# Altium schematic sheet [13] - AUDIO.SchDoc("[13] - AUDIO")
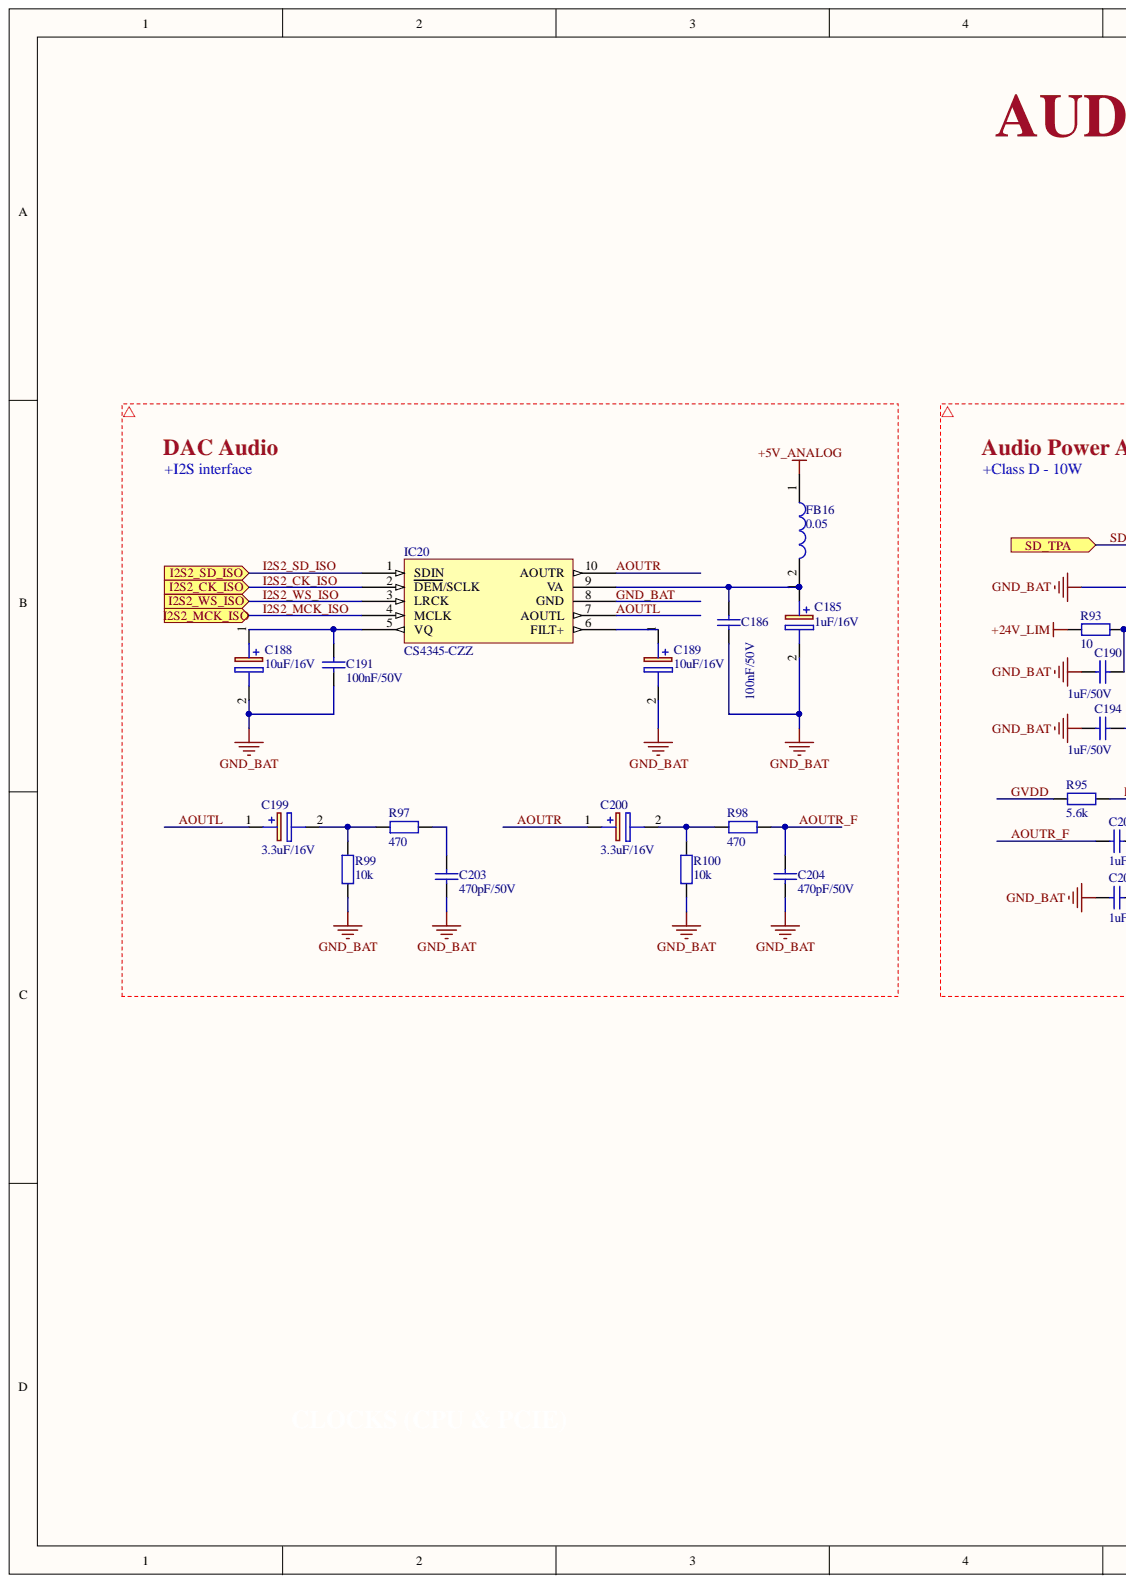
[diagram p0: [13] - AUDIO.SchDoc, left]
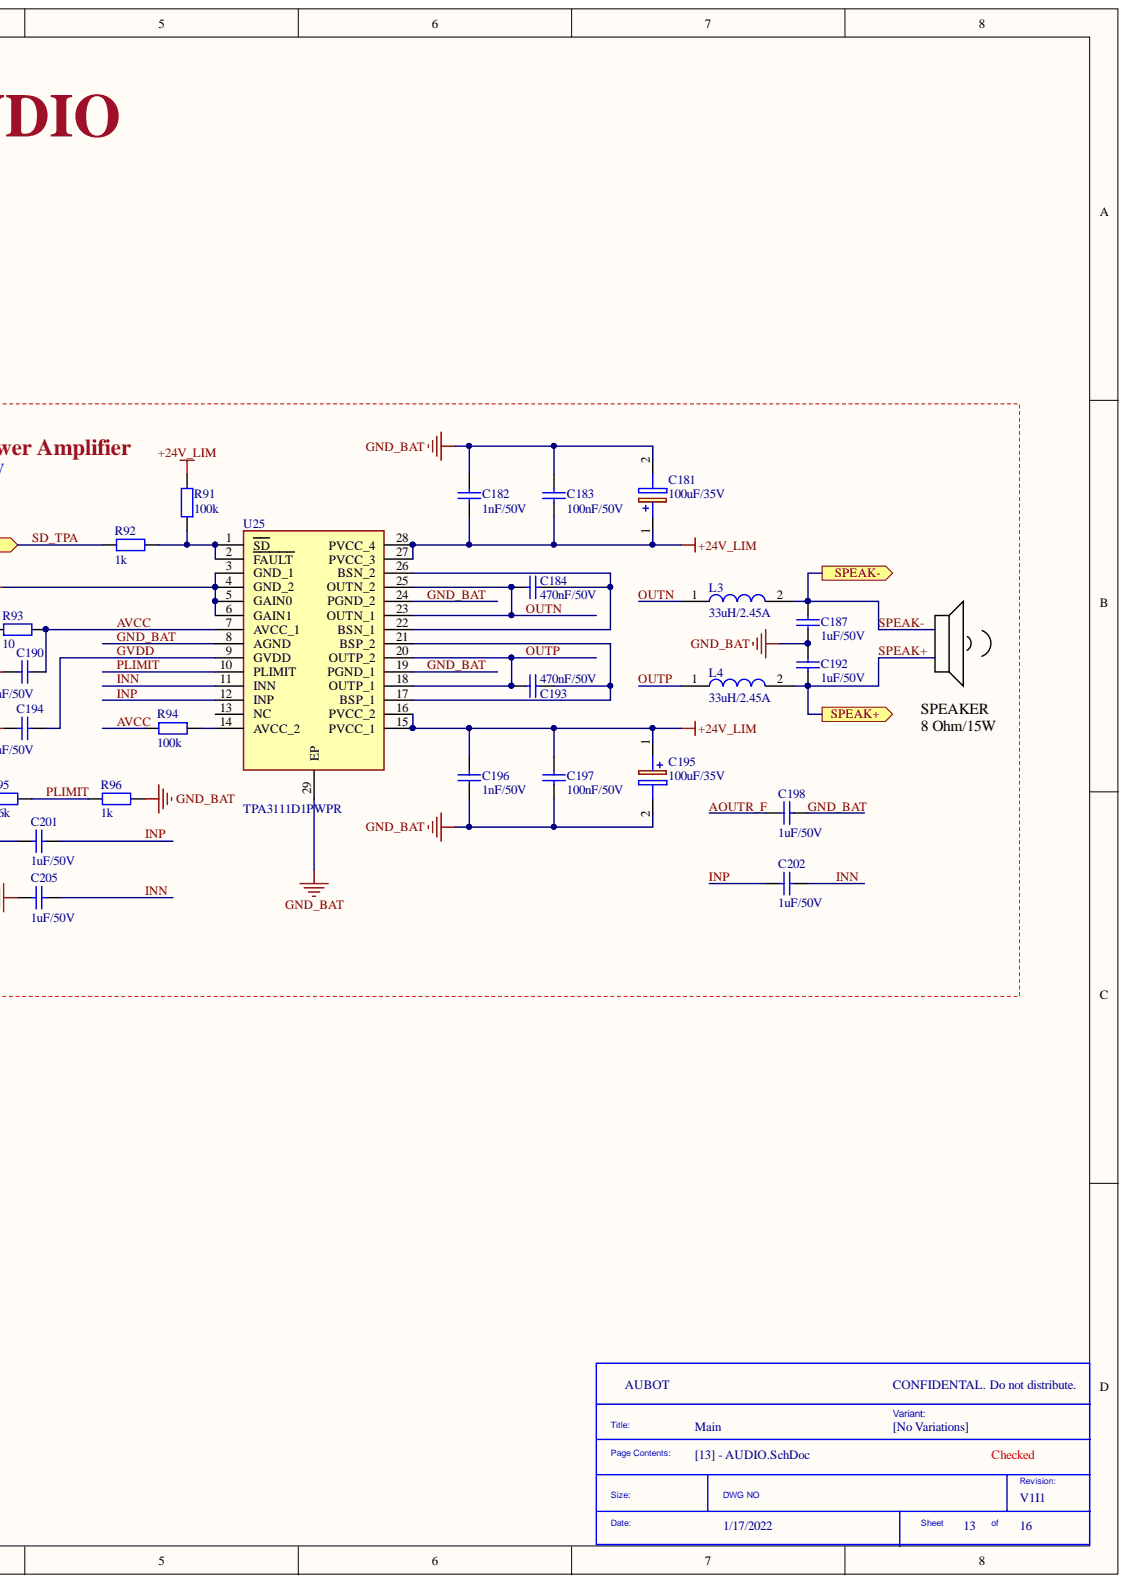
[diagram p1: [13] - AUDIO.SchDoc, right]

## Parts
C181  100uF/35V  [CAP 6.3X11]
C182  1nF/50V  [CAP 0805]
C183  100nF/50V  [CAP 0805]
C184  470nF/50V  [CAP 0805]
C185  1uF/16V  [CAP TANT 1206]
C186  100nF/50V  [CAP 0805]
C187  1uF/50V  [CAP 0805]
C188  10uF/16V  [CAP TANT 1206]
C189  10uF/16V  [CAP TANT 1206]
C190  1uF/50V  [CAP 0805]
C191  100nF/50V  [CAP 0805]
C192  1uF/50V  [CAP 0805]
C193  470nF/50V  [CAP 0805]
C194  1uF/50V  [CAP 0805]
C195  100uF/35V  [CAP 6.3X11]
C196  1nF/50V  [CAP 0805]
C197  100nF/50V  [CAP 0805]
C198  1uF/50V  [CAP 0805]
C199  3.3uF/16V  [CAP TANT 1206]
C200  3.3uF/16V  [CAP TANT 1206]
C201  1uF/50V  [CAP 0805]
C202  1uF/50V  [CAP 0805]
C203  470pF/50V  [CAP 0805]
C204  470pF/50V  [CAP 0805]
C205  1uF/50V  [CAP 0805]
FB16  0.05  [RES 0603]
IC20  CS4345-CZZ  [TSSOP-10]
L3  33uH/2.45A  [INDUCTOR RLB0913]
L4  33uH/2.45A  [INDUCTOR RLB0913]
R91  100k  [RES 0805]
R92  1k  [RES 0805]
R93  10  [RES 0805]
R94  100k  [RES 0805]
R95  5.6k  [RES 0805]
R96  1k  [RES 0805]
R97  470  [RES 0805]
R98  470  [RES 0805]
R99  10k  [RES 0805]
R100  10k  [RES 0805]
U25  TPA3111D1PWPR  [HTSSOP-28_POWERPAD]

## Ports
I2S2_CK_ISO, I2S2_MCK_ISO, I2S2_SD_ISO, I2S2_WS_ISO, SD_TPA, SPEAK+, SPEAK-

## Nets
+5V_ANALOG: FB16.1
+24V_LIM: C181.1 C182.1 C183.2 C195.1 C196.1 C197.1 R91.2 R93.1 U25.15(PVCC_1) U25.16(PVCC_2) U25.27(PVCC_3) U25.28(PVCC_4)
AOUTL: C199.1 IC20.7(AOUTL)
AOUTR: C200.1 IC20.10(AOUTR)
AOUTR_F: C198.2 C201.1 C204.1 R98.2
AVCC: C190.1 R93.2 R94.2 U25.7(AVCC_1)
GND_BAT: C181.2 C182.2 C183.1 C185.2 C186.2 C187.2 C188.2 C189.2 C190.2 C191.1 C192.1 C194.1 C195.2 C196.2 C197.2 C198.1 C203.2 C204.2 C205.1 IC20.8(GND) R96.2 R99.2 R100.2 U25.3(GND_1) U25.4(GND_2) U25.5(GAIN0) U25.6(GAIN1) U25.8(AGND) U25.19(PGND_1) U25.24(PGND_2) U25.29(EP)
GVDD: C194.2 R95.1 U25.9(GVDD)
I2S2_CK_ISO: IC20.2(DEM/SCLK) ; port I2S2_CK_ISO
I2S2_MCK_ISO: IC20.4(MCLK) ; port I2S2_MCK_ISO
I2S2_SD_ISO: IC20.1(SDIN) ; port I2S2_SD_ISO
I2S2_WS_ISO: IC20.3(LRCK) ; port I2S2_WS_ISO
INN: C202.1 C205.2 U25.11(INN)
INP: C201.2 C202.2 U25.12(INP)
OUTN: C184.2 L3.1 U25.23(OUTN_1) U25.25(OUTN_2)
OUTP: C193.2 L4.1 U25.18(OUTP_1) U25.20(OUTP_2)
PLIMIT: R95.2 R96.1 U25.10(PLIMIT)
SD_TPA: R92.1 ; port SD_TPA
SPEAK+: C192.2 L4.2 ; port SPEAK+
SPEAK-: C187.1 L3.2 ; port SPEAK-
NetC184_1: C184.1 U25.22(BSN_1) U25.26(BSN_2)
NetC185_1: C185.1 C186.1 FB16.2 IC20.9(VA)
NetC188_1: C188.1 C191.2 IC20.5(VQ)
NetC189_1: C189.1 IC20.6(FILT+)
NetC193_1: C193.1 U25.17(BSP_1) U25.21(BSP_2)
NetC199_2: C199.2 R97.1 R99.1
NetC200_2: C200.2 R98.1 R100.1
NetC203_1: C203.1 R97.2
NetR91_1: R91.1 R92.2 U25.1(SD) U25.2(FAULT)
NetR94_1: R94.1 U25.14(AVCC_2)

Not connected: U25 13(NC)

## Library data of the parts
C181 C195 = Cap Aluminum 100uF/35V: Alum. Electrolytic Cap 100µF 35V 6.3mm Radial WCAP-ATG8; Lifetime 2000h +85°C; Manufacturer Part Number: 860010573007; Manufacturer: Wurth Electronics; Tolerance: 20%; Ripple Current: 231mA; Polarity: Polar; Life (Hours): 2000Hour; Capacitance: 100uF; Voltage Rating (DC): 35V; Voltage Rating: 35V; Supplier Part Number 1: 732-8737-1-ND; Supplier 1: Digi-Key; Stock 1: 5828; RoHS Compliant: Yes; RoHS: Yes; REACH SVHC: Unknown; Pricing 1: 1 = 0.14000, 50 = 0.12200, 100 = 0.10700, 250 = 0.09400, 500 = 0.08300 (USD); Packaging: Tape and Reel; Package Quantity: 1; Mount: Through Hole; Min Operating Temperature: -40°C; Max Operating Temperature: 85°C; Lead Pitch: 0.098inch; Height - Seated (Max): 0.492inch; Height: 11mm; Diameter: 6.3mm; ComponentLink3URL: http://www.farnell.com/datasheets/1879547.pdf?src-supplier=Element14; ComponentLink3Description: http://www.farnell.com/datasheets/1879547.pdf?src-supplier=Element14; ComponentLink2URL: http://katalog.we-online.de/pbs/datasheet/860010573007.pdf?src-supplier=Digi-Key; ComponentLink2Description: http://katalog.we-online.de/pbs/datasheet/860010573007.pdf?src-supplier=Digi-Key; ComponentLink1URL: http://www.farnell.com/datasheets/1879547.pdf?src-supplier=Farnell; ComponentLink1Description: http://www.farnell.com/datasheets/1879547.pdf?src-supplier=Farnell; Component Kind: Standard; Case/Package: Radial; Library Name: Hieu_Lib.IntLib
C182 C196 = Cap 0805 1nF/50V: Surface Mount Ceramic Multilayer Capacitor 0.001uF 10% X7R 50V 0805 Paper T/R; Manufacturer Part Number: CC0805KRX7R9BB102; Manufacturer: Yageo; Insulation Resistance: 100GR; Capacitance: 1nF; Width: 0.049inch; Voltage Rating (DC): 50V; Voltage Rating: 50V; Voltage: 50V; Tolerance: 10%; Thickness: 0.024inch; Termination: SMD/SMT; Supplier Part Number 1: 311-1127-1-ND; Supplier 1: Digi-Key; Stock 1: 629072; Series: CC; RoHS Compliant: Yes; RoHS: Yes; REACH SVHC: No SVHC; Radiation Hardening: No; Pricing 1: 1 = 0.10000, 10 = 0.07000, 50 = 0.03800, 100 = 0.03100, 500 = 0.02214, 1000 = 0.01739 (USD); Packaging: Tape and Reel; Package Quantity: 1; Number of Pins: 2; Mount: Surface Mount; Min Operating Temperature: -55°C; Max Operating Temperature: 125°C; Material: Ceramic; Length: 2mm; Lead Free: Lead Free; Height: 0.6mm; Halogen Free: Halogen Free; Dielectric Material: Ceramic; Dielectric: X7R; Depth: 1.25mm; ComponentLink7URL: https://datasheet.ciiva.com/16625/upy-gphc-x7r-6-3v-to-50v-8-16625547.pdf?src-supplier=Avnet+Europe; ComponentLink7Description: https://datasheet.ciiva.com/16625/upy-gphc-x7r-6-3v-to-50v-8-16625547.pdf?src-supplier=Avnet+Europe; ComponentLink6URL: https://datasheet.ciiva.com/144/mlccorderingcode-144618.pdf?src-supplier=Digi-Key; ComponentLink6Description: https://datasheet.ciiva.com/144/mlccorderingcode-144618.pdf?src-supplier=Digi-Key; ComponentLink5URL: https://datasheet.ciiva.com/144/mlccorderingcode-144616.pdf?src-supplier=Digi-Key; ComponentLink5Description: https://datasheet.ciiva.com/144/mlccorderingcode-144616.pdf?src-supplier=Digi-Key; ComponentLink4URL: https://datasheet.ciiva.com/144/mlccorderingcode-144617.pdf?src-supplier=Digi-Key; ComponentLink4Description: https://datasheet.ciiva.com/144/mlccorderingcode-144617.pdf?src-supplier=Digi-Key; ComponentLink3URL: https://datasheet.ciiva.com/46393/1489800-46393302.pdf?src-supplier=Element14; ComponentLink3Description: https://datasheet.ciiva.com/46393/1489800-46393302.pdf?src-supplier=Element14; ComponentLink2URL: https://datasheet.ciiva.com/28450/1489800-28450797.pdf?src-supplier=Element14; ComponentLink2Description: https://datasheet.ciiva.com/28450/1489800-28450797.pdf?src-supplier=Element14; ComponentLink1URL: https://datasheet.ciiva.com/11786/0900766b80d84f1d-11786074.pdf?src-supplier=RSComponents; ComponentLink1Description: https://datasheet.ciiva.com/11786/0900766b80d84f1d-11786074.pdf?src-supplier=RSComponents; Component Kind: Standard; Case Code (Metric): 2012; Case Code (Imperial): 0805; Case/Package: 0805; Library Name: Hieu_Lib.IntLib
C183 C186 C191 C197 = Cap 0805 100nF/50V: Ceramic capacitor SMD-0805 100nF /50V X7R# K +-10%, Samsung, RoHS; Manufacturer Part Number: CL21B104KBCNNNC; Voltage Rating (DC): 50V; Tolerance: 10%; Dielectric: X7R; Width: 0.049inch; Voltage Rating: 50V; Voltage: 50V; Thickness: 0.037inch; Supplier Part Number 1: C1711; Supplier 1: LCSC; Stock 1: 447650; Series: CL; RoHS Compliant: Yes; RoHS: Yes; Radiation Hardening: No; Pricing 1: 50 = 0.04090, 500 = 0.03130, 1500 = 0.02890, 5000 = 0.02580, 25000 = 0.02430, 50000 = 0.02410, 50 = 0.03950, 500 = 0.03020, 1500 = 0.02790, 5000 = 0.02490, 25000 = 0.02350, 50000 = 0.02330, 50 = 0.20680, 500 = 0.15820, 1500 = 0.14600, 5000 = 0.13020, 25000 = 0.12290, 50000 = 0.12170, 50 = 0.19640, 500 = 0.15020, 1500 = 0.13860, 5000 = 0.12360, 25000 = 0.11670, 50000 = 0.11550, 50 = 0.02640, 500 = 0.02020, 1500 = 0.01860, 5000 = 0.01660, 25000 = 0.01570, 50000 = 0.01550, 50 = 0.02300, 500 = 0.01760, 1500 = 0.01620, 5000 = 0.01440, 25000 = 0.01360, 50000 = 0.01350, 50 = 0.24740, 500 = 0.18920, 1500 = 0.17470, 5000 = 0.15570, 25000 = 0.14700, 50000 = 0.14560, 50 = 3.45220, 500 = 2.64000, 1500 = 2.43700, 5000 = 2.17300, 25000 = 2.05110, 50000 = 2.03080, 50 = 0.13070, 500 = 0.09990, 1500 = 0.09220, 5000 = 0.08230, 25000 = 0.07760, 50000 = 0.07690, 50 = 2.39050, 500 = 1.82810, 1500 = 1.68750, 5000 = 1.50470, 25000 = 1.42030, 50000 = 1.40620, 50 = 0.26740, 500 = 0.20450, 1500 = 0.18870, 5000 = 0.16830, 25000 = 0.15890, 50000 = 0.15730, 50 = 0.04230, 500 = 0.03230, 1500 = 0.02990, 5000 = 0.02660, 25000 = 0.02510, 50000 = 0.02490, 50 = 0.03188, 500 = 0.02438, 1500 = 0.02251, 5000 = 0.02007, 25000 = 0.01894, 50000 = 0.01875 (AUD); Packaging: Tape and Reel; Mount: Surface Mount; Min Operating Temperature: -55°C; Max Operating Temperature: 125°C; Material: Ceramic; Manufacturer: Samsung; Length: 2mm; Lead Free: Lead Free; Height: 0.85mm; Depth: 1.25mm; Contact Plating: Tin; Construction: Flat; ComponentLink2URL: https://datasheet.ciiva.com/29199/454152-da-01-en-vs-kondensator-0805-29199814.pdf?src-supplier=Conrad; ComponentLink2Description: https://datasheet.ciiva.com/29199/454152-da-01-en-vs-kondensator-0805-29199814.pdf?src-supplier=Conrad; ComponentLink1URL: https://datasheet.ciiva.com/25710/cl21b104kbcnnnc-25710182.pdf?src-supplier=Digi-Key; ComponentLink1Description: https://datasheet.ciiva.com/25710/cl21b104kbcnnnc-25710182.pdf?src-supplier=Digi-Key; Component Kind: Standard; Case Code (Metric): 2012; Case Code (Imperial): 0805; Case/Package: 0805; Capacitance: 100nF; Library Name: Hieu_Lib.IntLib
C184 C193 = Cap 0805 470nF/50V: MLCC- CAPACITANCE 474; Manufacturer Part Number: CC0805KKX7R9BB474; Manufacturer: Yageo; Voltage Rating (DC): 50V; Tolerance: 10%; Dielectric: X7R; Capacitance: 470nF; Width: 0.049inch; Voltage Rating: 50V; Thickness: 0.057inch; Termination: SMD/SMT; Supplier Part Number 1: 311-1889-1-ND; Supplier 1: Digi-Key; Stock 1: 39761; Series: CC; RoHS Compliant: Yes; RoHS: Yes; Resistor Type: Ceramic; Radiation Hardening: No; Pricing 1: 1 = 0.25000, 10 = 0.17100, 50 = 0.10240, 100 = 0.08650, 500 = 0.05920, 1000 = 0.05237 (USD); Packaging: Tape and Reel; Mount: Surface Mount; Min Operating Temperature: -55°C; Max Operating Temperature: 125°C; Length: 2mm; Lead Free: Lead Free; Height: 1.25mm; Halogen Free: Halogen Free; Depth: 1.25mm; Construction: Flat; ComponentLink2URL: https://datasheet.ciiva.com/13263/upy-gphc-x7r-6-3v-to-50v-8-12304-13263301.pdf?src-supplier=Mouser; ComponentLink2Description: https://datasheet.ciiva.com/13263/upy-gphc-x7r-6-3v-to-50v-8-12304-13263301.pdf?src-supplier=Mouser; ComponentLink1URL: https://datasheet.ciiva.com/26862/getdatasheetpartid-403680-26862969.pdf?src-supplier=Verical; ComponentLink1Description: https://datasheet.ciiva.com/26862/getdatasheetpartid-403680-26862969.pdf?src-supplier=Verical; Component Kind: Standard; Case Code (Metric): 2012; Case Code (Imperial): 0805; Case/Package: 0805; Library Name: Hieu_Lib.IntLib
C185 = Cap Tant 1206 1uF/16V: Cap Tant Solid 1uF 16V A CASE 10%( 3.2 X 1.6 X 1.6mm) Inward L SMD 3216-18 11 Ohm 125C T/R; Manufacturer Part Number: TAJA105K016RNJ; Manufacturer: Kyocera AVX; Tolerance: 20%; Polarity: Polar; Leakage Current: 500nA; ESR (Equivalent Series Resistance): 11R; Dissipation Factor: 4%; Dielectric Material: Tantalum; Width: 1.6mm; Weight: 0.000571oz; Voltage Rating (DC): 16V; Voltage Rating: 16V; Voltage: 16V; Termination: SMD/SMT; Supplier Part Number 1: 478-1649-1-ND; Supplier 1: Digi-Key; Stock 1: 10000; Series: TAJ; RoHS Compliant: Yes; RoHS: Yes; REACH SVHC: No SVHC; Radiation Hardening: No; Pricing 1: 1 = 0.37000, 10 = 0.25500, 50 = 0.17880, 100 = 0.15320, 500 = 0.11488, 1000 = 0.09701 (USD); Packaging: Tape and Reel; Package Quantity: 1; Number of Pins: 2; Mount: Surface Mount,PCB; Min Operating Temperature: -55°C; Max Operating Temperature: 125°C; Length: 3.2mm; Lead Pitch: 1.1mm; Lead Free: Lead Free; Height - Seated (Max): 0.071inch; Height: 1.6mm; Features: General Purpose; Depth: 1.6mm; ComponentLink9URL: https://datasheet.ciiva.com/7832/1680476-7832431.pdf?src-supplier=Element14; ComponentLink9Description: https://datasheet.ciiva.com/7832/1680476-7832431.pdf?src-supplier=Element14; ComponentLink8URL: https://datasheet.ciiva.com/7832/1703059-7832429.pdf?src-supplier=Element14; ComponentLink8Description: https://datasheet.ciiva.com/7832/1703059-7832429.pdf?src-supplier=Element14; ComponentLink7URL: https://datasheet.ciiva.com/7832/taj-7832811.pdf?src-supplier=Element14; ComponentLink7Description: https://datasheet.ciiva.com/7832/taj-7832811.pdf?src-supplier=Element14; ComponentLink6URL: https://datasheet.ciiva.com/18710/taj-eng-18710647.pdf?src-supplier=Distrelec; ComponentLink6Description: https://datasheet.ciiva.com/18710/taj-eng-18710647.pdf?src-supplier=Distrelec; ComponentLink5URL: https://datasheet.ciiva.com/263/taj-263409.pdf?src-supplier=Digi-Key; ComponentLink5Description: https://datasheet.ciiva.com/263/taj-263409.pdf?src-supplier=Digi-Key; ComponentLink4URL: https://datasheet.ciiva.com/7832/1703059-7832430.pdf?src-supplier=Element14; ComponentLink4Description: https://datasheet.ciiva.com/7832/1703059-7832430.pdf?src-supplier=Element14; ComponentLink3URL: https://datasheet.ciiva.com/7832/taj-7832810.pdf?src-supplier=Element14; ComponentLink3Description: https://datasheet.ciiva.com/7832/taj-7832810.pdf?src-supplier=Element14; ComponentLink2URL: https://datasheet.ciiva.com/263/taj-263411.pdf?src-supplier=Digi-Key; ComponentLink2Description: https://datasheet.ciiva.com/263/taj-263411.pdf?src-supplier=Digi-Key; ComponentLink1URL: https://datasheet.ciiva.com/263/taj-263410.pdf?src-supplier=Digi-Key; ComponentLink1Description: https://datasheet.ciiva.com/263/taj-263410.pdf?src-supplier=Digi-Key; Component Kind: Standard; Case Code (Metric): 3216; Case Code (Imperial): 1206; Case/Package: 1206; Capacitance: 1uF; Library Name: Hieu_Lib.IntLib
C187 C190 C192 C194 C198 C201 C202 C205 = Cap 0805 1uF/50V: 0805 1 uF 50 V ±10 % Tolerance X7R SMT Multilayer Ceramic Capacitor; Manufacturer Part Number: CC0805KKX7R9BB105; Manufacturer: Yageo; Voltage Rating (DC): 50V; Tolerance: 10%; Capacitance: 1uF; Width: 0.049inch; Weight: 0.000194oz; Voltage Rating: 50V; Thickness: 0.057inch; Termination: SMD/SMT; Supplier Part Number 1: 311-1886-1-ND; Supplier 1: Digi-Key; Stock 1: 174238; Series: CC; RoHS Compliant: Yes; RoHS: Yes; Resistor Type: Ceramic; Radiation Hardening: No; Pricing 1: 1 = 0.26000, 10 = 0.17900, 50 = 0.11920, 100 = 0.10130, 500 = 0.07152, 1000 = 0.06257 (USD); Packaging: Tape and Reel; Mount: Surface Mount; Min Operating Temperature: -55°C; Max Operating Temperature: 125°C; Length: 2mm; Lead Free: Lead Free; Height: 1.25mm; Halogen Free: Halogen Free; Dielectric: X7R; Depth: 1.25mm; Construction: Flat; ComponentLink1URL: https://datasheet.ciiva.com/13209/upy-gphc-x7r-6-3v-to-50v-8-12304-13209375.pdf?src-supplier=Mouser; ComponentLink1Description: https://datasheet.ciiva.com/13209/upy-gphc-x7r-6-3v-to-50v-8-12304-13209375.pdf?src-supplier=Mouser; Component Kind: Standard; Case Code (Metric): 2012; Case Code (Imperial): 0805; Case/Package: 0805; Library Name: Hieu_Lib.IntLib
C188 C189 = Cap Tant 1206 10uF/16V: Cap Tant Solid 10uF 16V A CASE 20% (3.2 X 1.6 X 1.6mm) Inward L SMD 3216-18 125C T/R; Manufacturer Part Number: TCA1C106M8R; Manufacturer: Rohm; ESR (Equivalent Series Resistance): 3.5R; Width: 0.063inch; Voltage Rating (DC): 16V; Voltage Rating: 16V; Tolerance: 20%; Supplier Part Number 1: 511-1473-1-ND; Supplier 1: Digi-Key; Stock 1: 10000; Series: TC; RoHS Compliant: Yes; RoHS: Yes; Radiation Hardening: No; Pricing 1: 1 = 0.50000, 10 = 0.35200, 50 = 0.26660, 100 = 0.23210, 500 = 0.17194, 1000 = 0.14616 (USD); Packaging: Tape and Reel; Package Quantity: 1; Mount: Surface Mount; Min Operating Temperature: -55°C; Max Operating Temperature: 125°C; Length: 0.126inch; Lead Free: Lead Free; Height - Seated (Max): 0.071inch; Height: 1.6mm; Features: General Purpose; Depth: 1.6mm; Construction: Flat; ComponentLink1URL: https://datasheet.ciiva.com/16395/tc-a-16395735.pdf?src-supplier=Future+Electronics; ComponentLink1Description: https://datasheet.ciiva.com/16395/tc-a-16395735.pdf?src-supplier=Future+Electronics; Component Kind: Standard; Case Code (Metric): 3216; Case Code (Imperial): 1206; Case/Package: 1206; Capacitance: 10uF; Library Name: Hieu_Lib.IntLib
C199 C200 = Cap Tant 1206 3.3uF/16V: Cap Tant Solid 3.3uF 16V A CASE 20% (3.2 X 1.6 X 1.6mm) Inward L SMD 3216-18 5 Ohm 125C T/R; Manufacturer Part Number: TAJA335M016RNJ; Manufacturer: Kyocera AVX; Polarity: Polar; Leakage Current: 500nA; ESR (Equivalent Series Resistance): 5R; Dissipation Factor: 6%; Width: 0.063inch; Voltage Rating (DC): 16V; Voltage Rating: 16V; Tolerance: 20%; Termination: SMD/SMT; Supplier Part Number 1: 581-TAJA335M016R; Supplier 1: Mouser; Stock 1: 7941; RoHS: Yes; Radiation Hardening: No; Pricing 1: 1 = 0.46000, 10 = 0.25600, 50 = 0.25600, 100 = 0.19400, 1000 = 0.12300, 10000 = 0.12100 (USD); Packaging: Tape and Reel; Package Quantity: 1; Number of Pins: 2; Mount: Surface Mount; Min Operating Temperature: -55°C; Max Operating Temperature: 125°C; Length: 3.2mm; Lead Free: Lead Free; Height - Seated (Max): 0.071inch; Height: 1.8mm; Features: General Purpose; Depth: 1.6mm; ComponentLink4URL: https://datasheet.ciiva.com/16492/taj-16492793.pdf?src-supplier=Future+Electronics; ComponentLink4Description: https://datasheet.ciiva.com/16492/taj-16492793.pdf?src-supplier=Future+Electronics; ComponentLink3URL: https://datasheet.ciiva.com/12884/taj-27497-12884895.pdf?src-supplier=Mouser; ComponentLink3Description: https://datasheet.ciiva.com/12884/taj-27497-12884895.pdf?src-supplier=Mouser; ComponentLink2URL: https://datasheet.ciiva.com/11301/taj-11301101.pdf?src-supplier=Avnet; ComponentLink2Description: https://datasheet.ciiva.com/11301/taj-11301101.pdf?src-supplier=Avnet; ComponentLink1URL: https://datasheet.ciiva.com/11244/taj-11244006.pdf?src-supplier=Avnet; ComponentLink1Description: https://datasheet.ciiva.com/11244/taj-11244006.pdf?src-supplier=Avnet; Component Kind: Standard; Case Code (Metric): 3216; Case Code (Imperial): 1206; Case/Package: 1206; Capacitance: 3.3uF; Library Name: Hieu_Lib.IntLib
C203 C204 = Cap 0805 470pF/50V: 0805 470 pF 50 V ±10 % Tolerance X7R SMT Multilayer Ceramic Capacitor; Manufacturer Part Number: CC0805KRX7R9BB471; Manufacturer: Yageo; Voltage Rating (DC): 50V; Tolerance: 10%; Insulation Resistance: 100GR; Capacitance: 470pF; Width: 1.25mm; Voltage Rating: 50V; Thickness: 0.024inch; Termination: SMD/SMT; Supplier Part Number 1: 311-1124-1-ND; Supplier 1: Digi-Key; Stock 1: 190340; Series: CC; RoHS Compliant: Yes; RoHS: Yes; Resistor Type: Ceramic; REACH SVHC: Unknown; Radiation Hardening: No; Pricing 1: 1 = 0.11000, 10 = 0.08000, 50 = 0.04540, 100 = 0.03760, 500 = 0.02638, 1000 = 0.02184 (USD); Packaging: Tape and Reel; Package Quantity: 1; Number of Pins: 2; Mount: Surface Mount; Min Operating Temperature: -55°C; Max Operating Temperature: 125°C; Material: Ceramic; Length: 2mm; Lead Free: Lead Free; Height: 0.6mm; Halogen Free: Halogen Free; Dielectric Material: Ceramic; Dielectric: X7R; Depth: 1.25mm; Construction: Flat; ComponentLink6URL: https://datasheet.ciiva.com/15189/getdatasheetpartid-299872-15189229.pdf?src-supplier=Verical; ComponentLink6Description: https://datasheet.ciiva.com/15189/getdatasheetpartid-299872-15189229.pdf?src-supplier=Verical; ComponentLink5URL: https://datasheet.ciiva.com/46396/1489800-46396332.pdf?src-supplier=Element14; ComponentLink5Description: https://datasheet.ciiva.com/46396/1489800-46396332.pdf?src-supplier=Element14; ComponentLink4URL: https://datasheet.ciiva.com/144/mlccorderingcode-144600.pdf?src-supplier=Digi-Key; ComponentLink4Description: https://datasheet.ciiva.com/144/mlccorderingcode-144600.pdf?src-supplier=Digi-Key; ComponentLink3URL: https://datasheet.ciiva.com/144/mlccorderingcode-144599.pdf?src-supplier=Digi-Key; ComponentLink3Description: https://datasheet.ciiva.com/144/mlccorderingcode-144599.pdf?src-supplier=Digi-Key; ComponentLink2URL: https://datasheet.ciiva.com/144/mlccorderingcode-144598.pdf?src-supplier=Digi-Key; ComponentLink2Description: https://datasheet.ciiva.com/144/mlccorderingcode-144598.pdf?src-supplier=Digi-Key; ComponentLink1URL: https://datasheet.ciiva.com/29148/445173-da-01-en-kerko-chip-0805-x7r-470pf-10proz-50vg-29148961.pdf?src-supplier=Conrad; ComponentLink1Description: https://datasheet.ciiva.com/29148/445173-da-01-en-kerko-chip-0805-x7r-470pf-10proz-50vg-29148961.pdf?src-supplier=Conrad; Component Kind: Standard; Case Code (Metric): 2012; Case Code (Imperial): 0805; Case/Package: 0805; Library Name: Hieu_Lib.IntLib
FB16 = Ferrite Bead 0603 30Ohm 100Mhz: FERRITE BEAD 30 OHM 0603 1LN / Ferrite Beads Multi-Layer 30Ohm 100MHz 1A 0.05Ohm DCR 0603 T/R; Manufacturer Part Number: BLM18PG300SN1D; Manufacturer: Murata; Tolerance: 25%; Shielding: Unshielded; Number of Lines: 1; Material: Steel,Ferrite; Impedance: 30R; DC Resistance-Max: 50mR; Width: 0.8mm; Test Frequency: 100MHz; Termination: SMD/SMT; Supplier Part Number 1: 490-1035-1-ND; Supplier 1: Digi-Key; Stock 1: 1731; Series: BLM18P; RoHS Compliant: Yes; RoHS: Yes; REACH SVHC: No SVHC; Radiation Hardening: No; Pricing 1: 1 = 0.10000, 10 = 0.08300, 25 = 0.07600, 50 = 0.06840, 100 = 0.05010, 250 = 0.03644, 500 = 0.03340, 1000 = 0.02884 (USD); Packaging: Tape and Reel; Package Quantity: 4000; Number of Pins: 2; Number of Circuits: 1; Min Operating Temperature: -55°C; Max Operating Temperature: 125°C; Max DC Current: 1A; Max Current Rating: 1A; Length: 1.6mm; Lead Free: Lead Free; Height: 0.8mm; Frequency: 100MHz; Depth: 0.8mm; DC Resistance (DCR): 50mR; DC Current: 1A; Current Rating: 1A; Core Material: Ferrite; ComponentLink11URL: https://datasheet.ciiva.com/8021/5148-8021486.pdf?src-supplier=Element14; ComponentLink11Description: https://datasheet.ciiva.com/8021/5148-8021486.pdf?src-supplier=Element14; ComponentLink10URL: https://datasheet.ciiva.com/17246/l0110s0100blm18p-17246940.pdf?src-supplier=Avnet+Europe; ComponentLink10Description: https://datasheet.ciiva.com/17246/l0110s0100blm18p-17246940.pdf?src-supplier=Avnet+Europe; ComponentLink9URL: https://datasheet.ciiva.com/8021/5148-8021484.pdf?src-supplier=Element14; ComponentLink9Description: https://datasheet.ciiva.com/8021/5148-8021484.pdf?src-supplier=Element14; ComponentLink8URL: https://datasheet.ciiva.com/1012/l0110s0100blm18p-1012121.pdf?src-supplier=Digi-Key; ComponentLink8Description: https://datasheet.ciiva.com/1012/l0110s0100blm18p-1012121.pdf?src-supplier=Digi-Key; ComponentLink7URL: https://datasheet.ciiva.com/1012/l0110s0100blm18p-1012120.pdf?src-supplier=Digi-Key; ComponentLink7Description: https://datasheet.ciiva.com/1012/l0110s0100blm18p-1012120.pdf?src-supplier=Digi-Key; ComponentLink6URL: https://datasheet.ciiva.com/16420/l0110s0100blm18p-16420743.pdf?src-supplier=Future+Electronics; ComponentLink6Description: https://datasheet.ciiva.com/16420/l0110s0100blm18p-16420743.pdf?src-supplier=Future+Electronics; ComponentLink5URL: https://datasheet.ciiva.com/1012/l0110s0100blm18p-1012119.pdf?src-supplier=Digi-Key; ComponentLink5Description: https://datasheet.ciiva.com/1012/l0110s0100blm18p-1012119.pdf?src-supplier=Digi-Key; ComponentLink4URL: https://datasheet.ciiva.com/3081/l0110s0100blm18p-3081636.pdf?src-supplier=Avnet; ComponentLink4Description: https://datasheet.ciiva.com/3081/l0110s0100blm18p-3081636.pdf?src-supplier=Avnet; ComponentLink3URL: https://datasheet.ciiva.com/3081/l0110s0100blm18p-3081635.pdf?src-supplier=Avnet; ComponentLink3Description: https://datasheet.ciiva.com/3081/l0110s0100blm18p-3081635.pdf?src-supplier=Avnet; ComponentLink2URL: https://datasheet.ciiva.com/19460/1845048-19460200.pdf?src-supplier=Jameco; ComponentLink2Description: https://datasheet.ciiva.com/19460/1845048-19460200.pdf?src-supplier=Jameco; ComponentLink1URL: https://datasheet.ciiva.com/11800/0900766b81275ca5-11800868.pdf?src-supplier=RSComponents; ComponentLink1Description: https://datasheet.ciiva.com/11800/0900766b81275ca5-11800868.pdf?src-supplier=RSComponents; Component Kind: Standard; Case Code (Metric): 1608; Case Code (Imperial): 0603; Case/Package: 0603; Library Name: Hieu_Lib.IntLib
IC20 = CS4345-CZZ: DAC 2-CH Delta-Sigma 24-bit 10-Pin TSSOP Tube; Manufacturer: Cirrus Logic; Supply Type: Single; Supply Current: 22mA; Sampling Rate: 192000S/s; Resolution: 24b; Polarity: Bipolar; Output Type: Voltage; Operating Supply Voltage: 5V; Number of DAC Channels: 2; Number of Bits: 24; Min Supply Voltage: 3V; Max Supply Voltage: 5.25V; Max Power Dissipation: 69mW; Interface: SPI,Serial; Input Type: SERIAL; Conversion Rate: 192KS/s; Termination: SMD/SMT; Supplier Part Number 1: 598-1052-5-ND; Supplier 1: Digi-Key; Stock 1: 13400; Series: Popguard®; RoHS Compliant: Yes; RoHS: Yes; REACH SVHC: No SVHC; Pricing 1: 1 = 4.02000, 10 = 3.60800, 25 = 3.41040, 100 = 2.95580, 250 = 2.80424, 500 = 2.51622, 1000 = 2.14500 (USD); Packaging: Rail/Tube; Package Quantity: 96; Output Polarity: Bipolar; Number of Pins: 10; Number of D/A Converters: 2; Number of Converters: 2; Number of Channels: 2; Nominal Supply Current: 22mA; Mount: Surface Mount; Min Operating Temperature: -10°C; Max Operating Temperature: 70°C; Manufacturer Part Number: CS4345-CZZ; Lead Free: Lead Free; Contact Plating: Tin,Matte; ComponentLink5URL: https://datasheet.ciiva.com/3041/cs4344-45-46-48-f1-3041726.pdf?src-supplier=Avnet; ComponentLink5Description: https://datasheet.ciiva.com/3041/cs4344-45-46-48-f1-3041726.pdf?src-supplier=Avnet; ComponentLink4URL: https://datasheet.ciiva.com/16606/cs4344-45-46-48-f1-16606870.pdf?src-supplier=Avnet+Europe; ComponentLink4Description: https://datasheet.ciiva.com/16606/cs4344-45-46-48-f1-16606870.pdf?src-supplier=Avnet+Europe; ComponentLink3URL: https://datasheet.ciiva.com/1502/88361-1502954.pdf?src-supplier=Farnell; ComponentLink3Description: https://datasheet.ciiva.com/1502/88361-1502954.pdf?src-supplier=Farnell; ComponentLink2URL: https://datasheet.ciiva.com/1494/88361-1494229.pdf?src-supplier=Element14; ComponentLink2Description: https://datasheet.ciiva.com/1494/88361-1494229.pdf?src-supplier=Element14; ComponentLink1URL: https://datasheet.ciiva.com/26805/cs4345-czz-26805026.pdf?src-supplier=IIIC; ComponentLink1Description: https://datasheet.ciiva.com/26805/cs4345-czz-26805026.pdf?src-supplier=IIIC; Component Kind: Standard; Case/Package: TSSOP; Library Name: Hieu_Lib.IntLib
L3 L4 = Inductor 33uH/2.45A: Inductor Power Wirewound 33uH 10% 1KHz 30Q-Factor Ferrite 2.45A 90mOhm DCR RDL Tray; Manufacturer Part Number: RLB0913-330K; Manufacturer: Bourns; Shielding: Unshielded; Self Resonant Frequency: 7.8MHz; Saturation Current: 1.6A; DC Resistance (DCR): 90mR; Tolerance: 10%; Test Frequency: 1kHz; Supplier Part Number 1: RLB0913-330K-ND; Supplier 1: Digi-Key; Stock 1: 0; RoHS Compliant: Yes; RoHS: Yes; Resistor Type: Wirewound; Pricing 1: 1 = 0.85000, 10 = 0.74500, 25 = 0.69160, 40 = 0.58525, 200 = 0.53210, 400 = 0.50020, 600 = 0.44698, 1000 = 0.36184, 2400 = 0.34056 (USD); Packaging: Tray; Mount: Through Hole; Min Operating Temperature: -55°C; Max Operating Temperature: 125°C; Inductance: 33uH; Current Rating: 2.45A; Core Material: Ferrite; ComponentLink2URL: https://www.bourns.com/docs/Product-Datasheets/rlb0913.pdf?src-supplier=Digi-Key; ComponentLink2Description: https://www.bourns.com/docs/Product-Datasheets/rlb0913.pdf?src-supplier=Digi-Key; ComponentLink1URL: http://www.farnell.com/datasheets/2240884.pdf?src-supplier=Farnell; ComponentLink1Description: http://www.farnell.com/datasheets/2240884.pdf?src-supplier=Farnell; Component Kind: Standard; Case/Package: Radial; Library Name: Hieu_Lib.IntLib
R91 R94 = Res 0805 100k Ohm 1%: Res Thick Film 0805 100K Ohm 1% 1/8W ±100ppm/°C Molded SMD Paper T/R; Manufacturer Part Number: RC0805FR-07100KL; Manufacturer: Yageo; Voltage Rating (DC): 150V; Resistance: 100kR; Power Rating: 125mW; Width: 0.049inch; Voltage Rating: 150V; Tolerance: 1%; Termination: SMD/SMT; Temperature Coefficient: 100ppm/°C; Technology: THICK FILM; Supplier Part Number 1: 311-100KCRCT-ND; Supplier 1: Digi-Key; Stock 1: 537111; Size Code: 0805; Series: RC; RoHS Compliant: Yes; RoHS: Yes; Resistor Type: Thick Film; Resistance Tolerance: 1%; REACH SVHC: Unknown; Pricing 1: 1 = 0.10000, 10 = 0.04200, 100 = 0.01690, 1000 = 0.00759, 2500 = 0.00659 (USD); Packaging: Tape and Reel; Package Quantity: 1; Number of Terminations: 2; Number of Pins: 2; Min Operating Temperature: -55°C; Military Standard: Not; Max Power Dissipation: 125mW; Max Operating Temperature: 155°C; Length: 2mm; Lead Pitch: 0.4mm; Lead Free: Lead Free; Height: 0.024inch; Features: Moisture Resistant; Depth: 1.25mm; Construction: Rectangular; ComponentLink11URL: https://datasheet.ciiva.com/16747/rc0805-16747226.pdf?src-supplier=Future+Electronics; ComponentLink11Description: https://datasheet.ciiva.com/16747/rc0805-16747226.pdf?src-supplier=Future+Electronics; ComponentLink10URL: https://datasheet.ciiva.com/7941/1697719-7941678.pdf?src-supplier=Element14; ComponentLink10Description: https://datasheet.ciiva.com/7941/1697719-7941678.pdf?src-supplier=Element14; ComponentLink9URL: https://datasheet.ciiva.com/7941/1697719-7941676.pdf?src-supplier=Element14; ComponentLink9Description: https://datasheet.ciiva.com/7941/1697719-7941676.pdf?src-supplier=Element14; ComponentLink8URL: https://datasheet.ciiva.com/12667/rc0805-12667001.pdf?src-supplier=Mouser; ComponentLink8Description: https://datasheet.ciiva.com/12667/rc0805-12667001.pdf?src-supplier=Mouser; ComponentLink7URL: https://datasheet.ciiva.com/2457/pyu-r-int-thick-7-2457017.pdf?src-supplier=Digi-Key; ComponentLink7Description: https://datasheet.ciiva.com/2457/pyu-r-int-thick-7-2457017.pdf?src-supplier=Digi-Key; ComponentLink6URL: https://datasheet.ciiva.com/2457/pyu-r-int-thick-7-2457019.pdf?src-supplier=Digi-Key; ComponentLink6Description: https://datasheet.ciiva.com/2457/pyu-r-int-thick-7-2457019.pdf?src-supplier=Digi-Key; ComponentLink5URL: https://datasheet.ciiva.com/2457/pyu-r-int-thick-7-2457018.pdf?src-supplier=Digi-Key; ComponentLink5Description: https://datasheet.ciiva.com/2457/pyu-r-int-thick-7-2457018.pdf?src-supplier=Digi-Key; ComponentLink4URL: https://datasheet.ciiva.com/22747/444164-22747534.pdf?src-supplier=Element14; ComponentLink4Description: https://datasheet.ciiva.com/22747/444164-22747534.pdf?src-supplier=Element14; ComponentLink3URL: https://datasheet.ciiva.com/7660/38627-7660796.pdf?src-supplier=Element14; ComponentLink3Description: https://datasheet.ciiva.com/7660/38627-7660796.pdf?src-supplier=Element14; ComponentLink2URL: https://datasheet.ciiva.com/7941/1686128-7941677.pdf?src-supplier=Element14; ComponentLink2Description: https://datasheet.ciiva.com/7941/1686128-7941677.pdf?src-supplier=Element14; ComponentLink1URL: https://datasheet.ciiva.com/11808/0900766b8106beab-11808858.pdf?src-supplier=RSComponents; ComponentLink1Description: https://datasheet.ciiva.com/11808/0900766b8106beab-11808858.pdf?src-supplier=RSComponents; Component Kind: Standard; Case Code (Metric): 2012; Case Code (Imperial): 0805; Case/Package: 0805; Library Name: Hieu_Lib.IntLib
R92 R96 = Res 0805 1k Ohm 1%: Res Thick Film 0805 1KOhm 1% 0.125W(1/8W) 100ppm/C Epoxy Pad SMD T/R; Manufacturer Part Number: RC0805FR-071KL; Manufacturer: Yageo; Voltage Rating (DC): 150V; Temperature Coefficient: 100ppm/°C; Resistance: 1kR; Power Rating: 125mW; Width: 0.049inch; Voltage Rating: 150V; Tolerance: 1%; Termination: SMD/SMT; Supplier Part Number 1: 311-1.00KCRCT-ND; Supplier 1: Digi-Key; Stock 1: 2324983; Size Code: 0805; Series: RC; RoHS Compliant: Yes; RoHS: Yes; Resistor Type: Thick Film; Resistance Tolerance: 1%; REACH SVHC: Unknown; Pricing 1: 1 = 0.10000, 10 = 0.04200, 100 = 0.01690, 1000 = 0.00759, 2500 = 0.00659 (USD); Packaging: Tape and Reel; Package Quantity: 1; Number of Terminations: 2; Number of Pins: 2; Min Operating Temperature: -55°C; Military Standard: Not; Max Power Dissipation: 125mW; Max Operating Temperature: 155°C; Length: 0.079inch; Lead Free: Lead Free; Height: 0.024inch; Features: Moisture Resistant; Depth: 1.25mm; Construction: Rectangular; Component Kind: Standard; Case Code (Metric): 2012; Case Code (Imperial): 0805; Case/Package: 0805; Library Name: Hieu_Lib.IntLib
R93 = Res 0805 10 Ohm 1%: Res SMD 0805 10R 1% 0.125W T.C.100PPM; Manufacturer Part Number: RC0805FR-0710RL; Manufacturer: Yageo; Voltage Rating (DC): 150V; Temperature Coefficient: 200ppm/°C; Power Rating: 125mW; Width: 1.25mm; Voltage Rating: 150V; Tolerance: 1%; Termination: SMD/SMT; Technology: THICK FILM; Supplier Part Number 1: 311-10.0CRCT-ND; Supplier 1: Digi-Key; Stock 1: 0; Size Code: 0805; Series: RC; RoHS Compliant: Yes; RoHS: Yes; Resistor Type: Thick Film; Resistance Tolerance: 1%; Resistance: 10R; REACH SVHC: No SVHC; Packaging: Tape and Reel; Package Quantity: 1; Number of Terminations: 2; Number of Pins: 2; Min Operating Temperature: -55°C; Max Power Dissipation: 125mW; Max Operating Temperature: 155°C; Length: 2mm; Lead Free: Lead Free; Height: 0.5mm; Features: Moisture Resistant; Depth: 1.25mm; Construction: Rectangular; ComponentLink9URL: https://datasheet.ciiva.com/2457/pyu-r-int-thick-7-2457099.pdf?src-supplier=Digi-Key; ComponentLink9Description: https://datasheet.ciiva.com/2457/pyu-r-int-thick-7-2457099.pdf?src-supplier=Digi-Key; ComponentLink8URL: https://datasheet.ciiva.com/2457/pyu-r-int-thick-7-2457098.pdf?src-supplier=Digi-Key; ComponentLink8Description: https://datasheet.ciiva.com/2457/pyu-r-int-thick-7-2457098.pdf?src-supplier=Digi-Key; ComponentLink7URL: https://datasheet.ciiva.com/12555/rc0805-257173-12555112.pdf?src-supplier=Mouser; ComponentLink7Description: https://datasheet.ciiva.com/12555/rc0805-257173-12555112.pdf?src-supplier=Mouser; ComponentLink6URL: https://datasheet.ciiva.com/46447/444164-46447565.pdf?src-supplier=Element14; ComponentLink6Description: https://datasheet.ciiva.com/46447/444164-46447565.pdf?src-supplier=Element14; ComponentLink5URL: https://datasheet.ciiva.com/2457/pyu-r-int-thick-7-2457100.pdf?src-supplier=Digi-Key; ComponentLink5Description: https://datasheet.ciiva.com/2457/pyu-r-int-thick-7-2457100.pdf?src-supplier=Digi-Key; ComponentLink4URL: https://datasheet.ciiva.com/46412/444164-46412859.pdf?src-supplier=Element14; ComponentLink4Description: https://datasheet.ciiva.com/46412/444164-46412859.pdf?src-supplier=Element14; ComponentLink3URL: https://datasheet.ciiva.com/7941/444164-7941688.pdf?src-supplier=Element14; ComponentLink3Description: https://datasheet.ciiva.com/7941/444164-7941688.pdf?src-supplier=Element14; ComponentLink2URL: https://datasheet.ciiva.com/7941/444164-7941687.pdf?src-supplier=Element14; ComponentLink2Description: https://datasheet.ciiva.com/7941/444164-7941687.pdf?src-supplier=Element14; ComponentLink1URL: https://datasheet.ciiva.com/11809/0900766b8106beab-11809370.pdf?src-supplier=RSComponents; ComponentLink1Description: https://datasheet.ciiva.com/11809/0900766b8106beab-11809370.pdf?src-supplier=RSComponents; Component Kind: Standard; Case Code (Metric): 2012; Case Code (Imperial): 0805; Case/Package: 0805; Library Name: Hieu_Lib.IntLib
R95 = Res 0805 5.6k Ohm 1%: Res Thick Film 0805 5.6K Ohm 1% 0.125W(1/8W) ±100ppm/C Pad SMD T/R; Manufacturer Part Number: RC0805FR-075K6L; Manufacturer: Yageo; Voltage Rating: 150V; Temperature Coefficient: 100ppm/°C; Resistance: 5.6kR; Power Rating: 125mW; Width: 0.049inch; Tolerance: 1%; Termination: SMD/SMT; Supplier Part Number 1: 311-5.60KCRCT-ND; Supplier 1: Digi-Key; Stock 1: 312924; Size Code: 0805; Series: RC; RoHS Compliant: Yes; RoHS: Yes; Resistor Type: Thick Film; Resistance Tolerance: 1%; REACH SVHC: Unknown; Pricing 1: 1 = 0.10000, 10 = 0.04200, 100 = 0.01690, 1000 = 0.00759, 2500 = 0.00659 (USD); Packaging: Tape and Reel; Package Quantity: 1; Number of Terminations: 2; Min Operating Temperature: -55°C; Military Standard: Not; Max Power Dissipation: 125mW; Max Operating Temperature: 155°C; Length: 2mm; Lead Free: Lead Free; Height: 0.024inch; Features: Moisture Resistant; Depth: 1.25mm; Construction: Rectangular; ComponentLink5URL: https://datasheet.ciiva.com/7941/444164-7941820.pdf?src-supplier=Element14; ComponentLink5Description: https://datasheet.ciiva.com/7941/444164-7941820.pdf?src-supplier=Element14; ComponentLink4URL: https://datasheet.ciiva.com/7941/444164-7941819.pdf?src-supplier=Element14; ComponentLink4Description: https://datasheet.ciiva.com/7941/444164-7941819.pdf?src-supplier=Element14; ComponentLink3URL: https://datasheet.ciiva.com/2519/pyu-r-int-thick-7-2519845.pdf?src-supplier=Digi-Key; ComponentLink3Description: https://datasheet.ciiva.com/2519/pyu-r-int-thick-7-2519845.pdf?src-supplier=Digi-Key; ComponentLink2URL: https://datasheet.ciiva.com/2519/pyu-r-int-thick-7-2519844.pdf?src-supplier=Digi-Key; ComponentLink2Description: https://datasheet.ciiva.com/2519/pyu-r-int-thick-7-2519844.pdf?src-supplier=Digi-Key; ComponentLink1URL: https://datasheet.ciiva.com/2519/pyu-r-int-thick-7-2519843.pdf?src-supplier=Digi-Key; ComponentLink1Description: https://datasheet.ciiva.com/2519/pyu-r-int-thick-7-2519843.pdf?src-supplier=Digi-Key; Component Kind: Standard; Case Code (Metric): 2012; Case Code (Imperial): 0805; Case/Package: 0805; Library Name: Hieu_Lib.IntLib
R97 R98 = Res 0805 470 Ohm 1%: Res Thick Film 0805 470 Ohm 1% 1/8W +/-100ppm/ßC Molded SMD Paper T/R; Manufacturer Part Number: RC0805FR-07470RL; Manufacturer: Yageo; Voltage Rating (DC): 150V; Temperature Coefficient: 100ppm/°C; Resistance: 470R; Power Rating: 125mW; Width: 1.25mm; Voltage Rating: 150V; Tolerance: 1%; Termination: SMD/SMT; Supplier Part Number 1: 311-470CRDKR-ND; Supplier 1: Digi-Key; Stock 1: 0; Size Code: 0805; Series: RC; RoHS Compliant: Yes; RoHS: Yes; Resistor Type: Thick Film; Resistance Tolerance: 1%; REACH SVHC: Unknown; Pricing 1: 1 = 0.10000, 10 = 0.04200, 100 = 0.01690, 1000 = 0.00759, 2500 = 0.00659 (USD); Packaging: Tape and Reel; Package Quantity: 5000; Number of Terminations: 2; Number of Pins: 2; Min Operating Temperature: -55°C; Military Standard: Not; Max Power Dissipation: 125mW; Max Operating Temperature: 155°C; Length: 2mm; Lead Pitch: 0.4mm; Lead Free: Lead Free; Height: 0.5mm; Features: Moisture Resistant; Depth: 1.25mm; Construction: Rectangular; ComponentLink7URL: https://datasheet.ciiva.com/16745/rc0805-16745229.pdf?src-supplier=Future+Electronics; ComponentLink7Description: https://datasheet.ciiva.com/16745/rc0805-16745229.pdf?src-supplier=Future+Electronics; ComponentLink6URL: https://datasheet.ciiva.com/46447/444164-46447580.pdf?src-supplier=Element14; ComponentLink6Description: https://datasheet.ciiva.com/46447/444164-46447580.pdf?src-supplier=Element14; ComponentLink5URL: https://datasheet.ciiva.com/7941/444164-7941798.pdf?src-supplier=Element14; ComponentLink5Description: https://datasheet.ciiva.com/7941/444164-7941798.pdf?src-supplier=Element14; ComponentLink4URL: https://datasheet.ciiva.com/7941/444164-7941797.pdf?src-supplier=Element14; ComponentLink4Description: https://datasheet.ciiva.com/7941/444164-7941797.pdf?src-supplier=Element14; ComponentLink3URL: https://datasheet.ciiva.com/2457/pyu-r-int-thick-7-2457058.pdf?src-supplier=Digi-Key; ComponentLink3Description: https://datasheet.ciiva.com/2457/pyu-r-int-thick-7-2457058.pdf?src-supplier=Digi-Key; ComponentLink2URL: https://datasheet.ciiva.com/2457/pyu-r-int-thick-7-2457057.pdf?src-supplier=Digi-Key; ComponentLink2Description: https://datasheet.ciiva.com/2457/pyu-r-int-thick-7-2457057.pdf?src-supplier=Digi-Key; ComponentLink1URL: https://datasheet.ciiva.com/2457/pyu-r-int-thick-7-2457056.pdf?src-supplier=Digi-Key; ComponentLink1Description: https://datasheet.ciiva.com/2457/pyu-r-int-thick-7-2457056.pdf?src-supplier=Digi-Key; Component Kind: Standard; Case Code (Metric): 2012; Case Code (Imperial): 0805; Case/Package: 0805; Library Name: Hieu_Lib.IntLib
R99 R100 = Res 0805 10k Ohm 1%: Res SMD 0805 10K 1% 0.125W T.C.100PPM; Manufacturer Part Number: RC0805FR-0710KL; Manufacturer: Yageo; Voltage Rating: 150V; Temperature Coefficient: 100ppm/°C; Resistance: 10kR; Power Rating: 125mW; Width: 0.049inch; Tolerance: 1%; Termination: SMD/SMT; Technology: THICK FILM; Supplier Part Number 1: 311-10.0KCRCT-ND; Supplier 1: Digi-Key; Stock 1: 4587552; Size Code: 0805; Series: RC; RoHS Compliant: Yes; RoHS: Yes; Resistor Type: Thick Film; Resistance Tolerance: 1%; REACH SVHC: Unknown; Pricing 1: 1 = 0.10000, 10 = 0.04200, 100 = 0.01690, 1000 = 0.00759, 2500 = 0.00659 (USD); Packaging: Tape and Reel; Package Quantity: 1; Number of Terminations: 2; Min Operating Temperature: -55°C; Military Standard: Not; Max Power Dissipation: 125mW; Max Operating Temperature: 155°C; Length: 2mm; Lead Free: Lead Free; Height: 0.024inch; Features: Moisture Resistant; Depth: 1.25mm; Construction: Rectangular; Component Kind: Standard; Case Code (Metric): 2012; Case Code (Imperial): 0805; Case/Package: 0805; Library Name: Hieu_Lib.IntLib
U25 = TPA3111D1PWPR: Amplifier, Audio, Class D, 10W, HTSSOP-2; Msl: Msl 3 - 168 Hours; Svhc: No Svhc (15-JAN-2019); Manufacturer: Texas Instruments; Voltage Gain: 36dB; Supply Current: 40mA; Power Dissipation: 4.98W; Number of Channels: 1; Min Supply Voltage: 8V; Max Supply Voltage: 26V; Load Impedance: 8R; Gain: 37dB; Supplier Part Number 1: 296-25121-6-ND; Supplier 1: Digi-Key; Stock 1: 0; Series: SpeakerGuard™; RoHS Compliant: Yes; RoHS: Yes; REACH SVHC: No SVHC; Radiation Hardening: No; Pricing 1: 1 = 2.26000, 10 = 2.03400, 25 = 1.91840, 100 = 1.63460, 250 = 1.53488, 500 = 1.34302, 1000 = 1.26700 (USD); Packaging: Tape and Reel; Package Quantity: 2000; Output Power: 10W; Number of Pins: 28; Nominal Supply Current: 40mA; Min Operating Temperature: -40°C; Max Output Power: 10W; Max Operating Temperature: 85°C; Manufacturer Part Number: TPA3111D1PWPR; Lead Free: Lead Free; ComponentLink4URL: https://datasheet.ciiva.com/1789/tpa3111d1-1789051.pdf?src-supplier=Digi-Key; ComponentLink4Description: https://datasheet.ciiva.com/1789/tpa3111d1-1789051.pdf?src-supplier=Digi-Key; ComponentLink3URL: https://datasheet.ciiva.com/2969/tpa3111d1-2969959.pdf?src-supplier=Avnet; ComponentLink3Description: https://datasheet.ciiva.com/2969/tpa3111d1-2969959.pdf?src-supplier=Avnet; ComponentLink2URL: https://datasheet.ciiva.com/26842/tpa3111d1pwpr-26842084.pdf?src-supplier=IIIC; ComponentLink2Description: https://datasheet.ciiva.com/26842/tpa3111d1pwpr-26842084.pdf?src-supplier=IIIC; ComponentLink1URL: https://datasheet.ciiva.com/15131/getdatasheetpartid-376403-15131942.pdf?src-supplier=Verical; ComponentLink1Description: https://datasheet.ciiva.com/15131/getdatasheetpartid-376403-15131942.pdf?src-supplier=Verical; Component Kind: Standard; Case/Package: HTSSOP; Library Name: Hieu_Lib.SchLib
